# Altium schematic sheet MCU.SchDoc
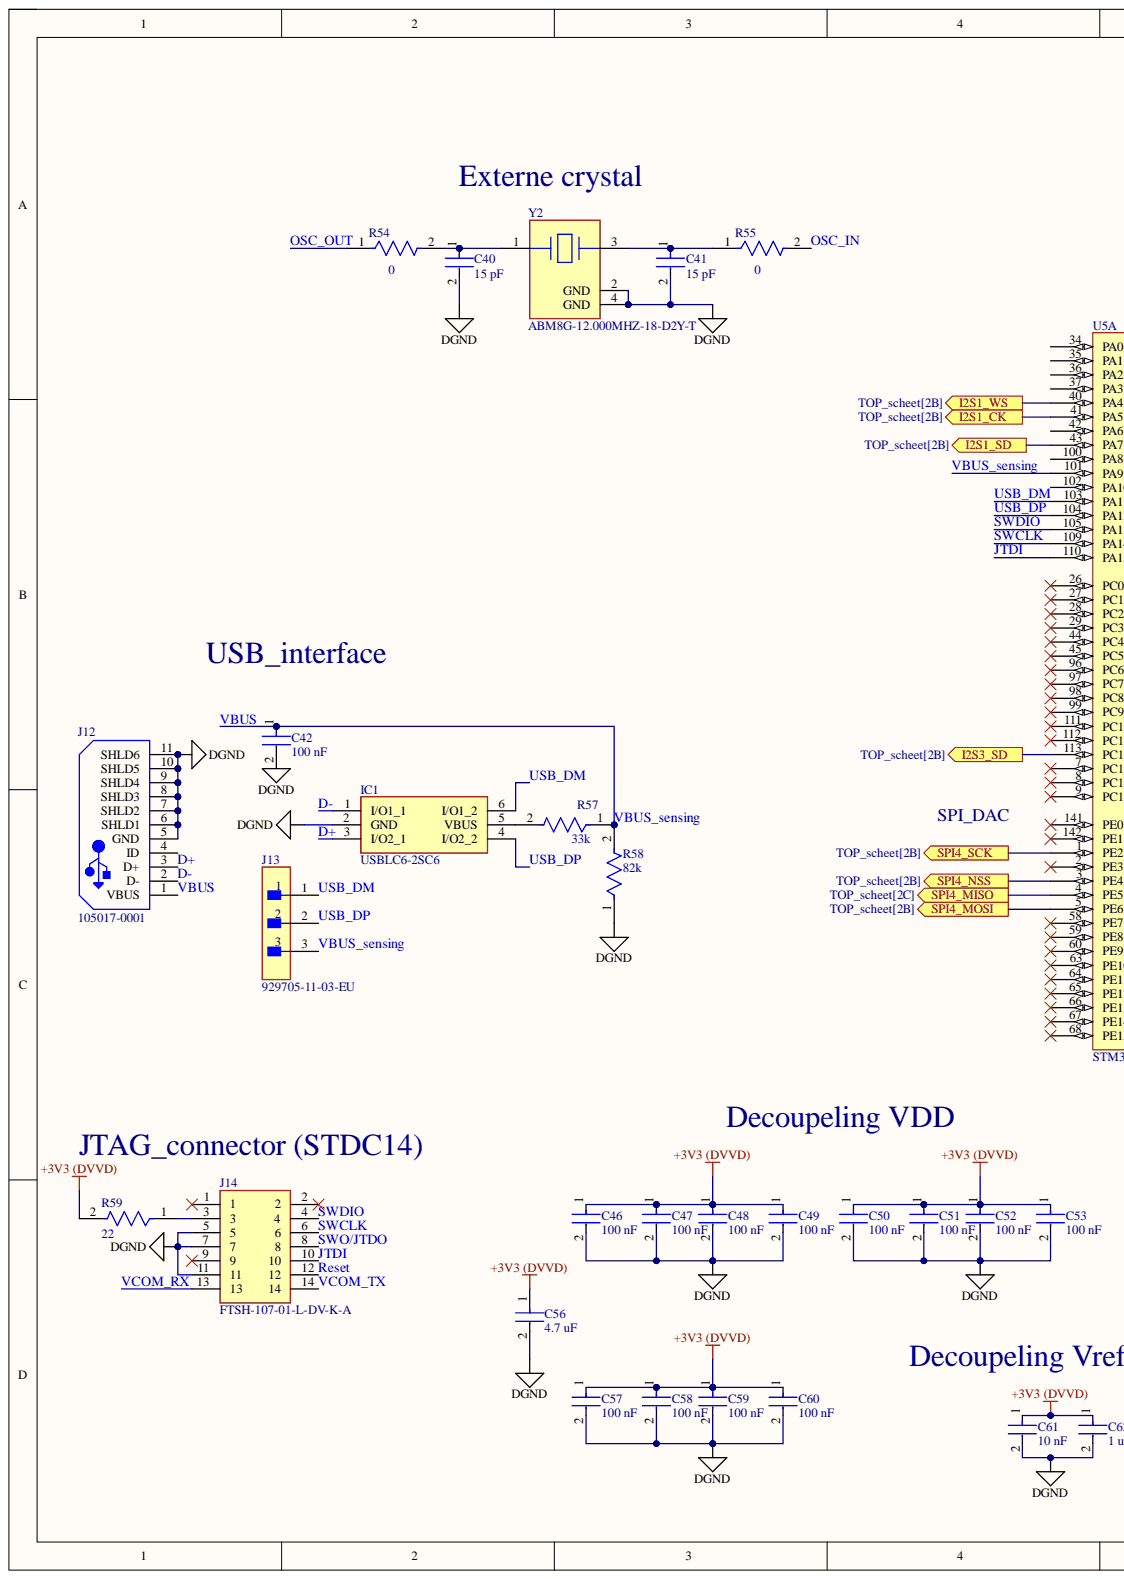
[diagram p0: MCU.SchDoc, left]
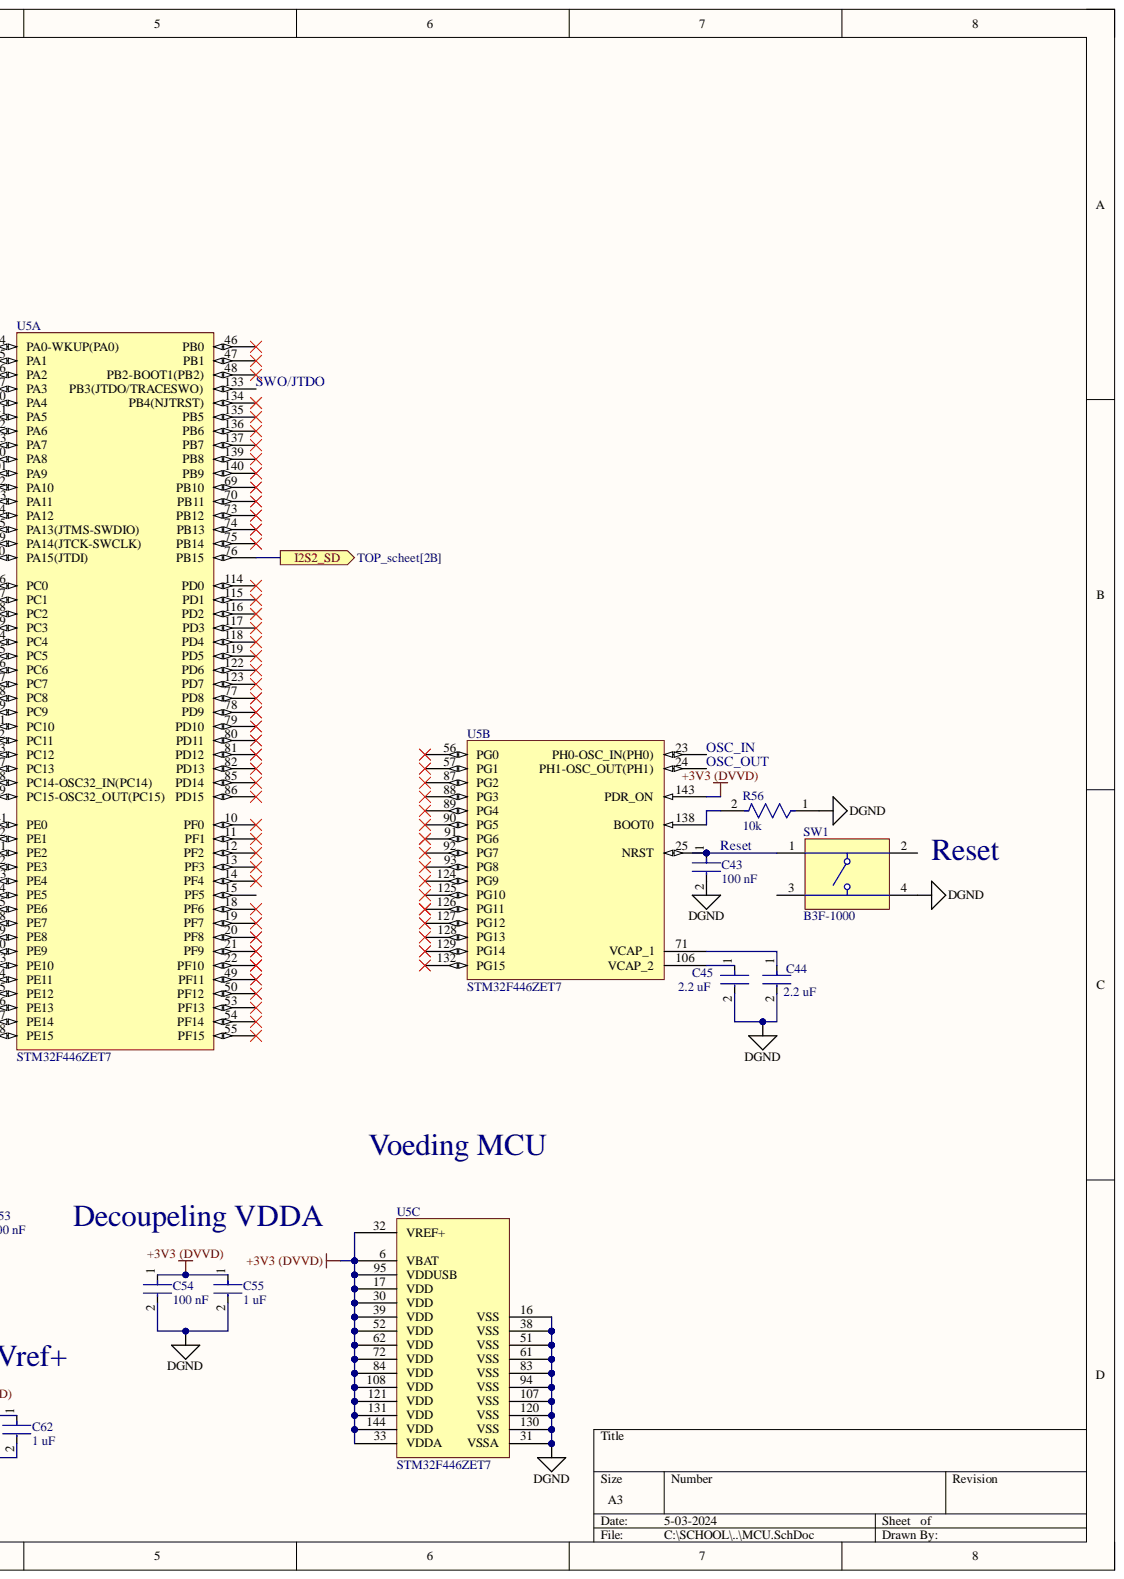
[diagram p1: MCU.SchDoc, right]

## Parts
C40  15 pF  [FP-AC0603-MFG]
C41  15 pF  [FP-AC0603-MFG]
C42  100 nF  [FP-C0603C-CF-MFG]
C43  100 nF  [FP-C0603C-CF-MFG]
C44  2.2 uF  [FP-C0603C-CF-MFG]
C45  2.2 uF  [FP-C0603C-CF-MFG]
C46  100 nF  [FP-C0603C-CF-MFG]
C47  100 nF  [FP-C0603C-CF-MFG]
C48  100 nF  [FP-C0603C-CF-MFG]
C49  100 nF  [FP-C0603C-CF-MFG]
C50  100 nF  [FP-C0603C-CF-MFG]
C51  100 nF  [FP-C0603C-CF-MFG]
C52  100 nF  [FP-C0603C-CF-MFG]
C53  100 nF  [FP-C0603C-CF-MFG]
C54  100 nF  [FP-C0603C-CF-MFG]
C55  1 uF  [FP-C0603C-CF-MFG]
C56  4.7 uF  [FP-C0603C-CF-MFG]
C57  100 nF  [FP-C0603C-CF-MFG]
C58  100 nF  [FP-C0603C-CF-MFG]
C59  100 nF  [FP-C0603C-CF-MFG]
C60  100 nF  [FP-C0603C-CF-MFG]
C61  10 nF  [FP-C0603C-CF-MFG]
C62  1 uF  [FP-C0603C-CF-MFG]
IC1  USBLC6-2SC6  [SOT95P280X145-6N]
J12  105017-0001  [USB-MICRO-B_V]
J13  929705-11-03-EU  [FP-929705-11-03-EU-MFG]
J14  FTSH-107-01-L-DV-K-A  [FTSH-107-XX-YYY-DV-K-A]
R54  0  [FP-CRCW1206-MFG]
R55  0  [FP-CRCW1206-MFG]
R56  10k  [FP-RC1608-IPC_B]
R57  33k  [FP-RC1608-IPC_B]
R58  82k  [FP-RC1608-IPC_B]
R59  22  [FP-RC1608-IPC_B]
SW1  B3F-1000  [OMRON-B3F-1000-4_V]
U5  STM32F446ZET7  [STM-LQFP144_M]  (parts A,B,C)
Y2  ABM8G-12.000MHZ-18-D2Y-T  [FP-ABM8G-MFG]

## Ports
I2S1_CK, I2S1_SD, I2S1_WS, I2S2_SD, I2S3_SD, SPI4_MISO, SPI4_MOSI, SPI4_NSS, SPI4_SCK

## Nets
+3V3 (DVVD): C46.1 C47.1 C48.1 C49.1 C50.1 C51.1 C52.1 C53.1 C54.1 C55.1 C56.1 C57.1 C58.1 C59.1 C60.1 C61.1 C62.1 R59.2 U5.6(VBAT) U5.17(VDD) U5.30(VDD) U5.32(VREF+) U5.33(VDDA) U5.39(VDD) U5.52(VDD) U5.62(VDD) U5.72(VDD) U5.84(VDD) U5.95(VDDUSB) U5.108(VDD) U5.121(VDD) U5.131(VDD) U5.143(PDR_ON) U5.144(VDD)
DGND: C40.2 C41.2 C42.2 C43.2 C44.2 C45.2 C46.2 C47.2 C48.2 C49.2 C50.2 C51.2 C52.2 C53.2 C54.2 C55.2 C56.2 C57.2 C58.2 C59.2 C60.2 C61.2 C62.2 IC1.2(GND) J12.5(GND) J12.6(SHLD1) J12.7(SHLD2) J12.8(SHLD3) J12.9(SHLD4) J12.10(SHLD5) J12.11(SHLD6) J14.5 J14.7 J14.11 R56.1 R58.1 SW1.4 U5.16(VSS) U5.31(VSSA) U5.38(VSS) U5.51(VSS) U5.61(VSS) U5.83(VSS) U5.94(VSS) U5.107(VSS) U5.120(VSS) U5.130(VSS) Y2.2(GND) Y2.4(GND)
D+: IC1.3(I/O2_1) J12.3(D+)
D-: IC1.1(I/O1_1) J12.2(D-)
I2S1_CK: U5.41(PA5) ; port I2S1_CK
I2S1_SD: U5.43(PA7) ; port I2S1_SD
I2S1_WS: U5.40(PA4) ; port I2S1_WS
I2S2_SD: U5.76(PB15) ; port I2S2_SD
I2S3_SD: U5.113(PC12) ; port I2S3_SD
JTDI: J14.10 U5.110(PA15(JTDI))
OSC_IN: R55.2 U5.23(PH0-OSC_IN(PH0))
OSC_OUT: R54.1 U5.24(PH1-OSC_OUT(PH1))
Reset: C43.1 J14.12 SW1.1 U5.25(NRST)
SPI4_MISO: U5.4(PE5) ; port SPI4_MISO
SPI4_MOSI: U5.5(PE6) ; port SPI4_MOSI
SPI4_NSS: U5.3(PE4) ; port SPI4_NSS
SPI4_SCK: U5.1(PE2) ; port SPI4_SCK
SWCLK: J14.6 U5.109(PA14(JTCK-SWCLK))
SWDIO: J14.4 U5.105(PA13(JTMS-SWDIO))
SWO/JTDO: J14.8 U5.133(PB3(JTDO/TRACESWO))
USB_DM: IC1.6(I/O1_2) J13.1 U5.103(PA11)
USB_DP: IC1.4(I/O2_2) J13.2 U5.104(PA12)
VBUS: C42.1 J12.1(VBUS) J13.3 R57.1 R58.2 U5.101(PA9)
VCOM_RX: J14.13
VCOM_TX: J14.14
NetC40_1: C40.1 R54.2 Y2.1
NetC41_1: C41.1 R55.1 Y2.3
NetC44_1: C44.1 U5.71(VCAP_1)
NetC45_1: C45.1 U5.106(VCAP_2)
NetIC1_5: IC1.5(VBUS) R57.2
NetJ14_3: J14.3 R59.1
NetR56_2: R56.2 U5.138(BOOT0)

Not connected: J12 4(ID); J14 1 2 9; SW1 2 3; U5 2(PE3) 7(PC13) 8(PC14-OSC32_IN(PC14)) 9(PC15-OSC32_OUT(PC15)) 10(PF0) 11(PF1) 12(PF2) 13(PF3) 14(PF4) 15(PF5) 18(PF6) 19(PF7) 20(PF8) 21(PF9) 22(PF10) 26(PC0) 27(PC1) 28(PC2) 29(PC3) 34(PA0-WKUP(PA0)) 35(PA1) 36(PA2) 37(PA3) 42(PA6) 44(PC4) 45(PC5) 46(PB0) 47(PB1) 48(PB2-BOOT1(PB2)) 49(PF11) 50(PF12) 53(PF13) 54(PF14) 55(PF15) 56(PG0) 57(PG1) 58(PE7) 59(PE8) 60(PE9) 63(PE10) 64(PE11) 65(PE12) 66(PE13) 67(PE14) 68(PE15) 69(PB10) 70(PB11) 73(PB12) 74(PB13) 75(PB14) 77(PD8) 78(PD9) 79(PD10) 80(PD11) 81(PD12) 82(PD13) 85(PD14) 86(PD15) 87(PG2) 88(PG3) 89(PG4) 90(PG5) 91(PG6) 92(PG7) 93(PG8) 96(PC6) 97(PC7) 98(PC8) 99(PC9) 100(PA8) 102(PA10) 111(PC10) 112(PC11) 114(PD0) 115(PD1) 116(PD2) 117(PD3) 118(PD4) 119(PD5) 122(PD6) 123(PD7) 124(PG9) 125(PG10) 126(PG11) 127(PG12) 128(PG13) 129(PG14) 132(PG15) 134(PB4(NJTRST)) 135(PB5) 136(PB6) 137(PB7) 139(PB8) 140(PB9) 141(PE0) 142(PE1)

## Library data of the parts
C40 C41 = CMP-03422-001062-1: AC0603 15 pF NP0 5.00% 50 V; Generic part Eurocircuits: GPC0603150; Manufacturer: Yageo; Manufacturer Part Number: AC0603JRNPO9BN271; Automotive Grade: Grade 1; Capacitance: 30 pF; Material: Ceramic; Temperature Coefficient: 15%; Tolerance: 0.05; Value: 30 pF; Voltage Rating: 50 V; Automotive: Yes; Category: Cap; Component Kind: Standard; ComponentLink2Description: Datasheet; ComponentLink2URL: http://www.yageo.com/documents/recent/UPY-AC_NP0X7R_16V-to-630V_12.pdf; Device Class L1: Passive Components; Device Class L2: Capacitors; Device Class L3: Ceramic Capacitors; Footprint Url: http://www.yageo.com/exep/pages/download/literatures/UPY-C_GEN_24.pdf; Height: 0.9mm; Ipc Land Pattern Name: CAPC16080X80; Lead Free: Yes; Library Name: Altium Content Vault; Octopart: https://octopart.com/ac0603jrnpo9bn271-yageo-87214073; Package: AC0603; RoHS: TRUE; Temperature: -55°C to +125°C; Temperature Characteristic: NP0; Temperature Range High: +125°C; Temperature Range Low: -55°C
C42 C43 C46-C54 C57-C60 = CMP-2006-03056-2: CAP CER 100 nF 50V 5% X7R 0603; Generic part Eurocircuits: GPC0603104; Manufacturer: KEMET; Manufacturer Part Number: C0603C104J5RACTU; Automotive Grade: Grade 1; Material: Ceramic; Temperature Coefficient: 30ppm/°C; Tolerance: 0.05; Automotive: Yes; Capacitance: 100 nF; Category: Cap; Component Kind: Standard; ComponentLink2Description: Datasheet; ComponentLink2URL: https://api.kemet.com/component-edge/download/datasheet/C0603C100J5GACAUTO.pdf; Device Class L1: Passive Components; Device Class L2: Capacitors; Device Class L3: Ceramic Capacitors; Digikey Description: CAP CER 0.1UF 50V 5% X7R 0603; Height: 0.87mm; Lead Free: Yes; Library Name: Altium Content Vault; Mouser Description: Multilayer Ceramic Capacitors MLCC - SMD/SMT 50volts 0.1uF X7R 5%; Octopart: https://octopart.com/c0603c104j5ractu-kemet-10440638; Package: C0603C-CF; RoHS: TRUE; Temperature: -55°C to +125°C; Temperature Characteristic: X7R; Temperature Range High: +125°C; Temperature Range Low: -55°C; Value: 100 nF; Voltage Rating: 50 V
C44 C45 = CMP-2006-03056-2: CAP CER 2.2 UF 50V 5% X7R 0603; Generic part Eurocircuits: GPC0603225-10; Manufacturer: KEMET; Manufacturer Part Number: C0603C104J5RACTU; Automotive Grade: Grade 1; Capacitance: 2.2 μF; Material: Ceramic; Temperature Coefficient: 30ppm/°C; Tolerance: 0.05; Value: 2.2 μF; Automotive: Yes; Category: Cap; Component Kind: Standard; ComponentLink2Description: Datasheet; ComponentLink2URL: https://api.kemet.com/component-edge/download/datasheet/C0603C100J5GACAUTO.pdf; Device Class L1: Passive Components; Device Class L2: Capacitors; Device Class L3: Ceramic Capacitors; Digikey Description: CAP CER 0.1UF 50V 5% X7R 0603; Height: 0.87mm; Lead Free: Yes; Library Name: Altium Content Vault; Mouser Description: Multilayer Ceramic Capacitors MLCC - SMD/SMT 50volts 0.1uF X7R 5%; Octopart: https://octopart.com/c0603c104j5ractu-kemet-10440638; Package: C0603C-CF; RoHS: TRUE; Temperature: -55°C to +125°C; Temperature Characteristic: X7R; Temperature Range High: +125°C; Temperature Range Low: -55°C; Voltage Rating: 50 V
C55 C62 = CMP-2006-03056-2: CAP CER 1 UF 50V 5% X7R 0603; Generic part Eurocircuits: GPC0603105; Manufacturer: KEMET; Manufacturer Part Number: C0603C104J5RACTU; Automotive Grade: Grade 1; Capacitance: 1 μF; Material: Ceramic; Temperature Coefficient: 30ppm/°C; Tolerance: 0.05; Value: 1 μF; Automotive: Yes; Category: Cap; Component Kind: Standard; ComponentLink2Description: Datasheet; ComponentLink2URL: https://api.kemet.com/component-edge/download/datasheet/C0603C100J5GACAUTO.pdf; Device Class L1: Passive Components; Device Class L2: Capacitors; Device Class L3: Ceramic Capacitors; Digikey Description: CAP CER 0.1UF 50V 5% X7R 0603; Height: 0.87mm; Lead Free: Yes; Library Name: Altium Content Vault; Mouser Description: Multilayer Ceramic Capacitors MLCC - SMD/SMT 50volts 0.1uF X7R 5%; Octopart: https://octopart.com/c0603c104j5ractu-kemet-10440638; Package: C0603C-CF; RoHS: TRUE; Temperature: -55°C to +125°C; Temperature Characteristic: X7R; Temperature Range High: +125°C; Temperature Range Low: -55°C; Voltage Rating: 50 V
C56 = CMP-2006-03056-2: CAP CER 4.7 UF 50V 5% X7R 0603; Generic part Eurocircuits: GPC0603475-10; Manufacturer: KEMET; Manufacturer Part Number: C0603C104J5RACTU; Automotive Grade: Grade 1; Capacitance: 4.7 μF; Material: Ceramic; Temperature Coefficient: 30ppm/°C; Tolerance: 0.05; Value: 4.7 μF; Automotive: Yes; Category: Cap; Component Kind: Standard; ComponentLink2Description: Datasheet; ComponentLink2URL: https://api.kemet.com/component-edge/download/datasheet/C0603C100J5GACAUTO.pdf; Device Class L1: Passive Components; Device Class L2: Capacitors; Device Class L3: Ceramic Capacitors; Digikey Description: CAP CER 0.1UF 50V 5% X7R 0603; Height: 0.87mm; Lead Free: Yes; Library Name: Altium Content Vault; Mouser Description: Multilayer Ceramic Capacitors MLCC - SMD/SMT 50volts 0.1uF X7R 5%; Octopart: https://octopart.com/c0603c104j5ractu-kemet-10440638; Package: C0603C-CF; RoHS: TRUE; Temperature: -55°C to +125°C; Temperature Characteristic: X7R; Temperature Range High: +125°C; Temperature Range Low: -55°C; Voltage Rating: 50 V
C61 = CMP-2006-03056-2: CAP CER 100 nF 50V 5% X7R 0603; Generic part Eurocircuits: GPC0603103; Manufacturer: KEMET; Manufacturer Part Number: C0603C104J5RACTU; Automotive Grade: Grade 1; Material: Ceramic; Temperature Coefficient: 30ppm/°C; Tolerance: 0.05; Automotive: Yes; Capacitance: 10 nF; Category: Cap; Component Kind: Standard; ComponentLink2Description: Datasheet; ComponentLink2URL: https://api.kemet.com/component-edge/download/datasheet/C0603C100J5GACAUTO.pdf; Device Class L1: Passive Components; Device Class L2: Capacitors; Device Class L3: Ceramic Capacitors; Digikey Description: CAP CER 0.1UF 50V 5% X7R 0603; Height: 0.87mm; Lead Free: Yes; Library Name: Altium Content Vault; Mouser Description: Multilayer Ceramic Capacitors MLCC - SMD/SMT 50volts 0.1uF X7R 5%; Octopart: https://octopart.com/c0603c104j5ractu-kemet-10440638; Package: C0603C-CF; RoHS: TRUE; Temperature: -55°C to +125°C; Temperature Characteristic: X7R; Temperature Range High: +125°C; Temperature Range Low: -55°C; Value: 10 nF; Voltage Rating: 50 V
IC1 = USBLC6-2SC6: ESD-onderdrukkers/TVS-diodes ESD Protection Low Cap; Manufacturer_Name: STMicroelectronics; Bedrijfsspanning: 5.25 V; Doorslagspanning: 6 V; Klemspanning: 17 V; Arrow Part Number: USBLC6-2SC6; Arrow Price/Stock: https://www.arrow.com/en/products/usblc6-2sc6/stmicroelectronics?region=nac; Component Kind: Standard; Datasheet Link: http://www.st.com/st-web-ui/static/active/en/resource/technical/document/datasheet/CD00050750.pdf; Height: 1.45mm; Manufacturer_Part_Number: USBLC6-2SC6; Mouser Part Number: 511-USBLC6-2SC6; Mouser Price/Stock: https://www.mouser.co.uk/ProductDetail/STMicroelectronics/USBLC6-2SC6?qs=po45yt2pPpu%2FhNIlwQdTlg%3D%3D
J12 = CMP-2000-05827-1: Micro-USB B Receptacle, Right Angle, Bottom Mount, Surface Mount, with Solder Tabs, -30 to 85 degC, 5-Pin USB, RoHS, Tape and Reel; Manufacturer: Molex; 3D Model: 105017-0001.stp; Catalog Drawings: 1050170001; Circuits: 5; Connector Type: USB-microB; Featured Product: Micro-USBConnectorsUSBConnectors; Mating Products: WM17145-ND-CABLEMICROUSBBTOSTDA1.0MWM17147-ND-CABLEMICROUSBBTOSTDA2.0MWM17146-ND-CABLEMICROUSBBTOSTDA1.5M; Online Catalog: Molex-MicroUSB; Other Names: WM1399DKR; Package Version: Rev. F, 12/2012; PCN Assembly/Origin: ResinSupplier21/Oct/2015; Voltage - Max: 30V; Category: Connectors,Interconnects; Component Kind: Standard; Datasheet URL: http://www.molex.com/elqNow/elqRedir.htm?ref=http://www.molex.com/webdocs/datasheets/pdf/en-us/1050170001_IO_CONNECTORS.pdf; Datasheet Version: 03/2016; Family: USB,DVI,HDMIConnectors; Features: Shielded,SolderRetention; Gender: Receptacle; Ingress Protection: -; Library Name: Altium Content Vault; Manufacturer URL: http://www.molex.com/; Mounting Technology: SurfaceMount; Mounting Type: BoardCutout,SurfaceMount,RightAngle; Number of Contacts: 5; Package Description: 5-Leads USB, Micro B, Body 7.5 x 5 mm, Pitch 0.65 mm; Package Reference: MICRO-B; Package URL: http://www.molex.com/pdm_docs/sd/1050170001_sd.pdf; Packaging: Digi-Reel®; RoHS Information: 1050170001CertofCompliance; Series: -; Specifications: -; Standard Package: 1
J13 = CMP-05379-000017-1: CONN HEADER VERT 3POS 2.54MM  Euro circruits generic component:  GPHA-1X03; Connector Type: Male Pin; Current Rating: 2.5A; Gender: Male; Number of Positions: 3; Voltage Rating: 1.5kV; Color: Black; Component Kind: Standard; Contact Gender: Male; Contact Material: Copper Alloy; Contact Mating Length: 0.318inch; Contact Plating: Gold; Contact Type: Male Pin; Depth: 2.92mm; Eurocircuits_generic_part_number: GPHA-1X03; Housing Color: Black; Housing Material: Polycyclohexylenedimethylene; Length: 7.6mm; Library Name: Altium Content Vault; Max Current Rating: 2.5A; Max Operating Temperature: 105°C; Min Operating Temperature: -40°C; Mount: Through Hole; Number of Rows: 1; Orientation: Straight; Package Quantity: 100; Packaging: Bulk; Pitch: 2.54mm; RoHS Compliant: Yes; Series: 929; Termination: Solder
J14 = FTSH-107-01-L-DV-K-A: Board Connector, 14 Contact(s), 2 Row(s), Male, Straight, 0.05 inch Pitch, Surface Mount Terminal, Locking, Receptacle; Manufacturer_Name: SAMTEC; Number of Contacts: 14; Pitch: 1.27mm; Arrow Part Number: FTSH-107-01-L-DV-K-A; Arrow Price/Stock: https://www.arrow.com/en/products/ftsh-107-01-l-dv-k-a/samtec?region=europe; Component Kind: Standard; Datasheet Link: http://suddendocs.samtec.com/prints/ftsh-1xx-xx-xxx-dv-xxx-xxx-mkt.pdf; Gender: Male; Manufacturer_Part_Number: FTSH-107-01-L-DV-K-A; Mouser Part Number: 200-FTSH10701LDVKA; Mouser Price/Stock: https://www.mouser.co.uk/ProductDetail/Samtec/FTSH-107-01-L-DV-K-A?qs=PB6%2FjmICvI3xEHFou4PhNg%3D%3D
R54 R55 = CMP-00030-39388935-1: CRCW12060000ZSTA Zero Ohm Resistor, Jumper, 1206 [3216 Metric], Thick Film, 250 mW, Surface Mount; Max Power Dissipation: 250mW; Resistance: 0Ω; Temperature Coefficient: 100ppm/°C; Voltage Rating: 200V; Case Code (Imperial): 1206; Case Code (Metric): 3216; Case/Package: 1206; Component Kind: Standard; Composition: Thick Film; Contact Plating: Lead, Tin; Depth: 1.6mm; Features: Automotive AEC-Q200; Height: 609.6µm; Lead Free: Contains Lead; Length: 3.2mm; Library Name: Altium Content Vault; Max Operating Temperature: 155°C; Military Standard: Not; Min Operating Temperature: -55°C; Mount: Surface Mount; NexarPartId: 39388935; Number of Terminations: 2; Packaging: Cut Tape; Power Rating: 250mW; Radiation Hardening: No; REACH SVHC: Unknown; RoHS: Compliant; Termination: SMD/SMT; Voltage Rating (DC): 200V; Weight: 10.007382mg; Width: 1.6mm
R56 = CMP-13261-004935-1: Res Thick Film 0603 10kΩ 1% 0.1W ±100ppm/°C Molded SMD T/R    Generic part Eurocircuits:   GPR060310K; Power Rating: 100mW; Voltage Rating (DC): 50V; Case/Package: 0603; Component Kind: Standard; Composition: Thick Film; Contact Plating: Tin; Depth: 0.8mm; Generic part Eurocircuits: GPR060310K; Lead Free: Lead Free; Length: 1.6mm; Library Name: Altium Content Vault; Max Operating Temperature: 155°C; Military Standard: Not; Min Operating Temperature: -55°C; Packaging: Tape and Reel; Radiation Hardening: No; Resistance: 10k; Temperature Coefficient: 100ppm/°C; Tolerance: 1%; Width: 800um
R57 = CMP-13261-004935-1: Res Thick Film 0603 33kΩ 1% 0.1W ±100ppm/°C Molded SMD T/R    Generic part Eurocircuits:   GPR060310K; Generic part Eurocircuits: GPR060333K; Power Rating: 100mW; Voltage Rating (DC): 50V; Case/Package: 0603; Component Kind: Standard; Composition: Thick Film; Contact Plating: Tin; Depth: 0.8mm; Lead Free: Lead Free; Length: 1.6mm; Library Name: Altium Content Vault; Max Operating Temperature: 155°C; Military Standard: Not; Min Operating Temperature: -55°C; Packaging: Tape and Reel; Radiation Hardening: No; Resistance: 33k; Temperature Coefficient: 100ppm/°C; Tolerance: 1%; Width: 800um
R58 = CMP-13261-004935-1: Res Thick Film 0603 82kΩ 1% 0.1W ±100ppm/°C Molded SMD T/R    Generic part Eurocircuits:   GPR060310K; Generic part Eurocircuits: GPR060382K; Power Rating: 100mW; Voltage Rating (DC): 50V; Case/Package: 0603; Component Kind: Standard; Composition: Thick Film; Contact Plating: Tin; Depth: 0.8mm; Lead Free: Lead Free; Length: 1.6mm; Library Name: Altium Content Vault; Max Operating Temperature: 155°C; Military Standard: Not; Min Operating Temperature: -55°C; Packaging: Tape and Reel; Radiation Hardening: No; Resistance: 82k; Temperature Coefficient: 100ppm/°C; Tolerance: 1%; Width: 800um
R59 = CMP-13261-004935-1: Res Thick Film 0603 22Ω 1% 0.1W ±100ppm/°C Molded SMD T/R    Generic part Eurocircuits:   GPR060310K; Generic part Eurocircuits: GPR060322R; Power Rating: 100mW; Voltage Rating (DC): 50V; Case/Package: 0603; Component Kind: Standard; Composition: Thick Film; Contact Plating: Tin; Depth: 0.8mm; Lead Free: Lead Free; Length: 1.6mm; Library Name: Altium Content Vault; Max Operating Temperature: 155°C; Military Standard: Not; Min Operating Temperature: -55°C; Packaging: Tape and Reel; Radiation Hardening: No; Resistance: 22; Temperature Coefficient: 100ppm/°C; Tolerance: 1%; Width: 800um
SW1 = CMP-1677-00002-1: Tactile Switch, SPST-NO, 0.05 A, 5 to 24 V, -25 to 70 degC, 4-Pin THD, RoHS, Bulk; Capacitance: -45 f; Current Rating: 1.5 A; Gender: Female; Shielding: Shielded; Voltage Rating: 150 V; Component Kind: Standard; ComponentLink1Description: Manufacturer URL; ComponentLink1URL: http://www.omron.com/; ComponentLink2Description: Datasheet; ComponentLink2URL: http://www.omron.com/ecb/products/pdf/en-b3f.pdf; Contact Material: Brass; Contact Plating: Gold; DatasheetVersion: Jan-15; Height: 14.99 mm; Lead Pitch: 1.27 mm; Length: 18.34 mm; Library Name: Altium Content Vault; Max Operating Temperature: 70 degC; Min Operating Temperature: -40 degC; Mount: Through Hole; Package Quantity: 1080; PackageDescription: 4-Pin Through Hole Device, Body 6 x 6 mm; PackageReference: B3F-1000; Packaging: Tray; Pins: 8; Radiation Hardening: No; RoHSCompliant: true; Width: 25.4 mm
U5 = CMP-0243-00227-1: ARM Cortex-M4 32-bit MCU+FPU, 512 KB Flash, 128 KB Internal RAM, 114 I/Os, 144-Pin LQFP, -40 to 105 degC, Tray; D/A Converter: 2; FLASH Size (Prog) (kB): 512; I/Os (High Current): 114; Internal RAM Size (kB): 128; Operating Frequency(F) (Processor speed) (MHz): 180; Supply Voltage(Vcc) min (V): 1.7; Component Kind: Standard; ComponentLink1Description: Manufacturer URL; ComponentLink1URL: http://www.st.com/; ComponentLink2Description: Datasheet; ComponentLink2URL: http://www.st.com/st-web-ui/static/active/en/resource/technical/document/datasheet/DM00141306.pdf; Core: ARM Cortex-M4; DatasheetVersion: Rev. 6; Library Name: Altium Content Vault; Mounting Technology: Surface Mount; Package Description: LQFP144; Package Reference: 144-Pin Low Profile Quad Flat Package, Body 20 x 20 mm, Pitch 0.5 mm; Packing: Tray
Y2 = CMP-2000-05039-3: Crystal 12MHz ±20ppm (Tol) ±30ppm (Stability) 18pF FUND 120Ω 4-Pin SMD T/R; ESR (Equivalent Series Resistance): 120R; Case/Package: CSMD; Component Kind: Standard; Depth: 2.5mm; Frequency: 12MHz; Frequency Stability: 30ppm; Frequency Tolerance: 20ppm; Height: 1mm; Lead Free: Lead Free; Length: 3.2mm; Library Name: Altium Content Vault; Load Capacitance: 18pF; Max Operating Temperature: 85°C; Min Operating Temperature: -40°C; Mount: Surface Mount; Number of Pins: 4; Operating Frequency: 12 MHz; Package Quantity: 1000; Packaging: Tape and Reel; Radiation Hardening: No; Series: ABM8G; Series Resistance: 120R; Termination: SMD/SMT; Tolerance: 20ppm; Width: 2.5mm
